# Altium schematic sheet STM32-ROMI_Rev_A_4_3V_5V.SchDoc(STM32-ROMI_Rev_A_4_3V_5V)
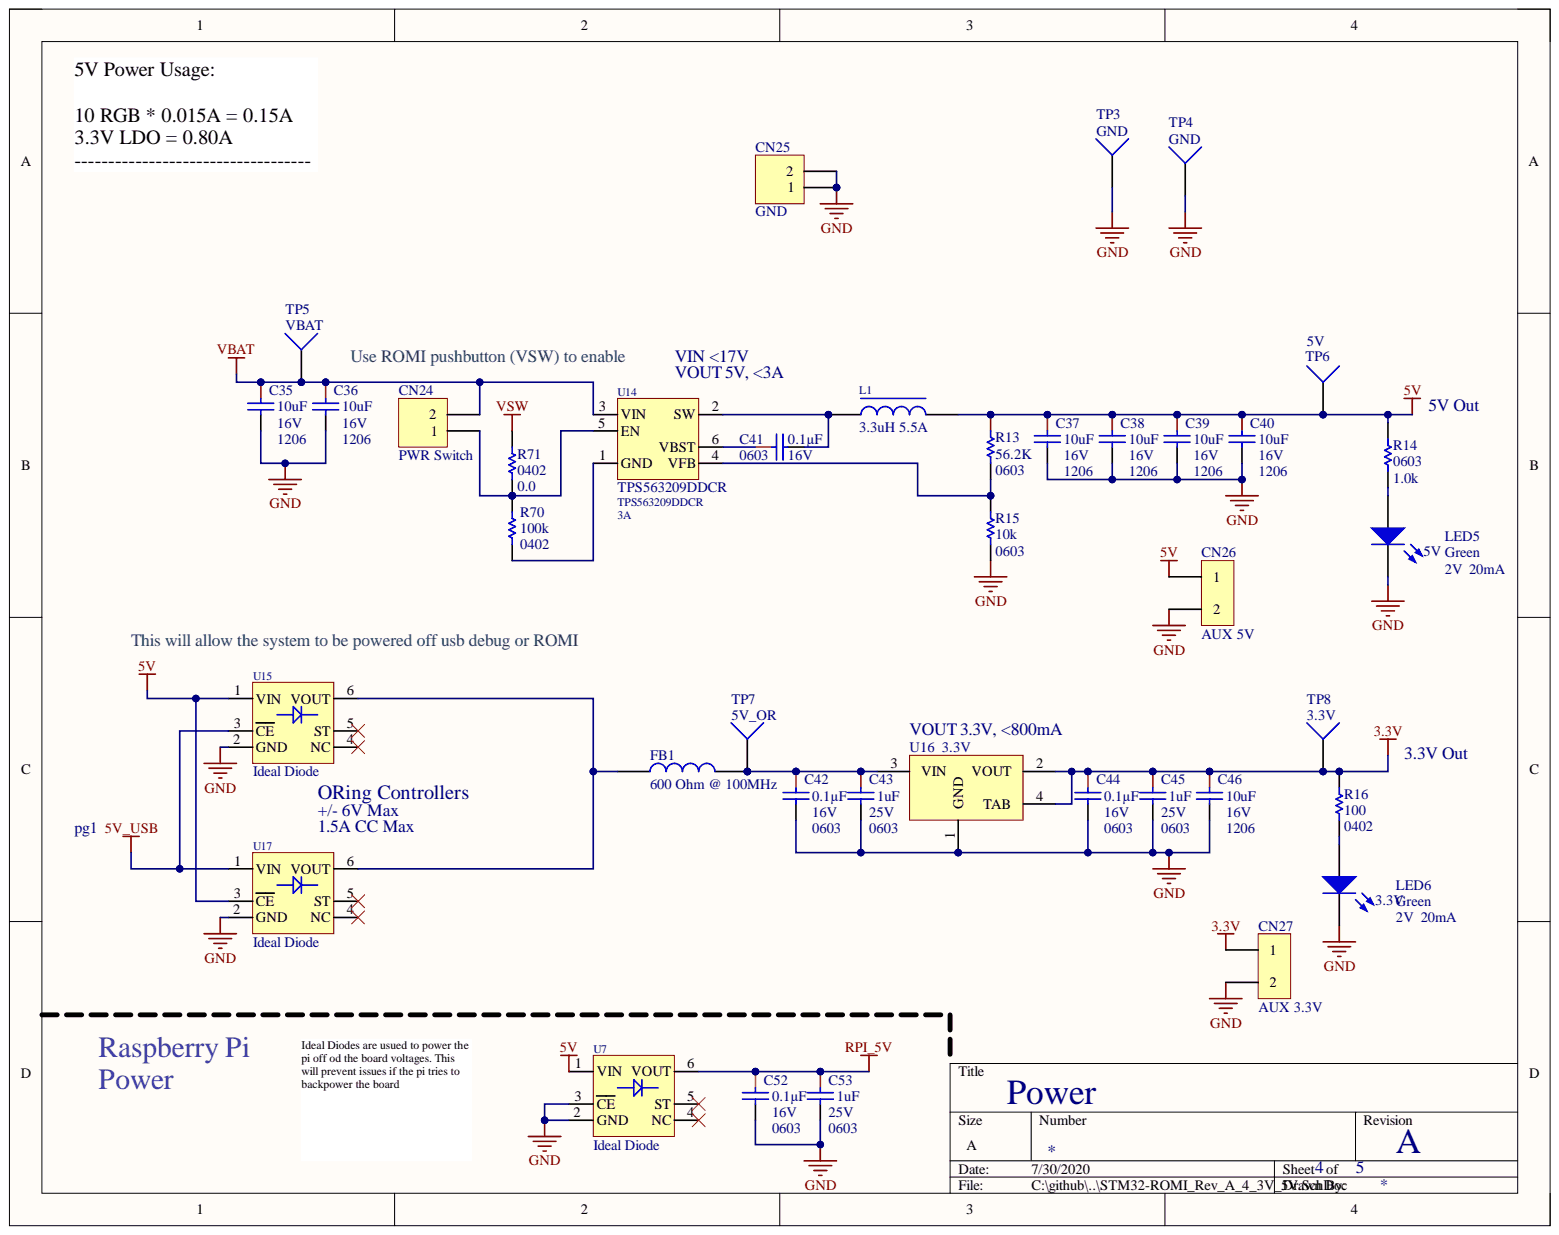
[diagram p0: STM32-ROMI_Rev_A_4_3V_5V.SchDoc]

## Parts
C35  10uF  Package: 1206  Voltage - Rated: 16V  [CAPC1206(3216)_M]
C36  10uF  Package: 1206  Voltage - Rated: 16V  [CAPC1206(3216)_M]
C37  10uF  Package: 1206  Voltage - Rated: 16V  [CAPC1206(3216)_M]
C38  10uF  Package: 1206  Voltage - Rated: 16V  [CAPC1206(3216)_M]
C39  10uF  Package: 1206  Voltage - Rated: 16V  [CAPC1206(3216)_M]
C40  10uF  Package: 1206  Voltage - Rated: 16V  [CAPC1206(3216)_M]
C41  Capacitance: 0.1µF  Package / Case: 0603  Voltage - Rated: 16V  [CAPC0603(1608)_L]  (hidden comment: 0.1uF)
C42  Capacitance: 0.1µF  Package / Case: 0603  Voltage - Rated: 16V  [CAPC0603(1608)_L]  (hidden comment: 0.1uF)
C43  1uF  Package / Case: 0603  Voltage - Rated: 25V  [CAPC0603(1608)_N]
C44  Capacitance: 0.1µF  Package / Case: 0603  Voltage - Rated: 16V  [CAPC0603(1608)_L]  (hidden comment: 0.1uF)
C45  1uF  Package / Case: 0603  Voltage - Rated: 25V  [CAPC0603(1608)_N]
C46  10uF  Package: 1206  Voltage - Rated: 16V  [CAPC1206(3216)_M]
C52  Capacitance: 0.1µF  Package / Case: 0603  Voltage - Rated: 16V  [CAPC0603(1608)_L]  (hidden comment: 0.1uF)
C53  1uF  Package / Case: 0603  Voltage - Rated: 25V  [CAPC0603(1608)_N]
CN24  PWR Switch  [HDR1X2]
CN25  GND  [HDR1X2]
CN26  AUX 5V  [Molex 1x2 Microfit 3.0]
CN27  AUX 3.3V  [Molex 1x2 Microfit 3.0]
FB1  600 Ohm @ 100MHz  [RESC0805(2012)_N]
L1  3.3uH 5.5A  [YSPI0530-3R3M]
LED5  5V  Color: Green  Voltage - Forward (Vf) (Typ): 2V  [SM-LED-0603]
LED6  3.3V  Color: Green  Voltage - Forward (Vf) (Typ): 2V  [SM-LED-0603]
R13  56.2K  Package / Case: 0603  [RESC0603(1608)_L]
R14  Package / Case: 0603  [RESC0603(1608)_N]  (hidden comment: 1.0K)
R15  Package / Case: 0603  Resistance (Ohms): 10k  [RESC0603(1608)_L]  (hidden comment: 10K 1%)
R16  100  Supplier Device Package: 0402  [RESC0402(1005)_N]
R70  Resistance (Ohms): 100k  Supplier Device Package: 0402  [RESC0402(1005)_L]  (hidden comment: 100K 0402)
R71  0  Resistance (Ohms): 0.0  Supplier Device Package: 0402  [RESC0402(1005)_L]
TP3  GND  [TestPoint-SM]  (no BOM)
TP4  GND  [TestPoint-SM]  (no BOM)
TP5  VBAT  [TestPoint-SM]  (no BOM)
TP6  5V  [TestPoint-SM]  (no BOM)
TP7  5V_OR  [TestPoint-SM]  (no BOM)
TP8  3.3V  [TestPoint-SM]  (no BOM)
U7  Ideal Diode  [SC-70-6]
U14  TPS563209DDCR  [SOT23-6]
U15  Ideal Diode  [SC-70-6]
U16  3.3V  [DSO-G3]
U17  Ideal Diode  [SC-70-6]

## Nets
3.3V: C44.1 C45.1 C46.1 CN27.1 R16.2 TP8.1 U16.2(VOUT) U16.4(TAB)
5V: C37.1 C38.1 C39.1 C40.1 CN26.1 L1.2 R13.1 R14.2 TP6.1 U7.1(VIN) U15.1(VIN) U17.3(CE)
5V_USB: U15.3(CE) U17.1(VIN)
GND: C35.2 C36.2 C37.2 C38.2 C39.2 C40.2 C42.2 C43.2 C44.2 C45.2 C46.2 C52.2 C53.2 CN25.1 CN25.2 CN26.2 CN27.2 LED5.2 LED6.2 R15.1 TP3.1 TP4.1 U7.2(GND) U7.3(CE) U15.2(GND) U16.1(GND) U17.2(GND)
RPI_5V: C52.1 C53.1 U7.6(VOUT)
VBAT: C35.1 C36.1 CN24.2 TP5.1 U14.3(VIN)
VSW: R71.1
NetC41_1: C41.1 U14.6(VBST)
NetC41_2: C41.2 L1.1 U14.2(SW)
NetC42_1: C42.1 C43.1 FB1.2 TP7.1 U16.3(VIN)
NetCN24_1: CN24.1 R70.2 R71.2 U14.5(EN)
NetFB1_1: FB1.1 U15.6(VOUT) U17.6(VOUT)
NetLED5_1: LED5.1 R14.1
NetLED6_1: LED6.1 R16.1
NetR13_2: R13.2 R15.2 U14.4(VFB)
NetR70_1: R70.1 U14.1(GND)

Not connected: U7 4(NC) 5(ST); U15 4(NC) 5(ST); U17 4(NC) 5(ST)

## Library data of the parts
C35-C40 C46 = CAP CER 10UF 16V 1206: Temperature Coefficient: X7R; Capacitance: 10uF; Component Kind: Standard; LCSC: C126600; Supplier 1: Digi-Key; Supplier Part Number 1: 490-4793-1-ND; Library Name: Kyle-Passive.IntLib
C41 C42 C44 C52 = CAP CER 0.1UF 16V 10% X7R 0603: 0.1uF 0603 16V; Manufacturer: Kemet; Manufacturer Part Number: C0603C104K4RACTU; Temperature Coefficient: X7R; Tolerance: ±10%; Applications: General Purpose; Category: Capacitors; Component Kind: Standard; ComponentLink1Description: http://www.kemet.com/datasheets&C0603C104K4RACTU; ComponentLink1URL: http://www.kemet.com/datasheets&C0603C104K4RACTU; Features: -; Height - Seated (Max): -; Lead Spacing: -; Lead Style: -; Mounting Type: Surface Mount, MLCC; Operating Temperature: -55°C ~ 125°C; Packaging: Tape & Reel (TR); Pricing 1: 4000=0.00414, 8000=0.00378, 12000=0.0036, 28000=0.00342, 100000=0.00248 (USD); Ratings: -; RoHS: RoHS Compliant; Size / Dimension: 0.063" L x 0.031" W (1.60mm x 0.80mm); Stock 1: 2360000; Supplier 1: Digi-Key; Supplier Part Number 1: 399-1096-2-ND; Thickness (Max): 0.034" (0.87mm); Library Name: Kyle-Passive.IntLib
C43 C45 C53 = CAP CER 1UF 25V 10% X7R 0603: 1uF 0603 25V; Manufacturer: TDK Corporation; Manufacturer Part Number: CGA3E1X7R1E105K080AC; Temperature Coefficient: X7R; Tolerance: ±10%; Applications: Automotive; Category: Capacitors; Component Kind: Standard; ComponentLink1Description: https://product.tdk.com/info/en/catalog/datasheets/mlcc_automotive_general_en.pdf; ComponentLink1URL: https://product.tdk.com/info/en/catalog/datasheets/mlcc_automotive_general_en.pdf; Failure Rate: -; Features: -; Height - Seated (Max): -; Lead Spacing: -; Lead Style: -; Library Name: OLogic-Passive.IntLib; Mounting Type: Surface Mount, MLCC; Operating Temperature: -55°C ~ 125°C; Packaging: Cut Tape (CT); Part Status: Active; Pricing 1: 1=0.2, 10=0.135, 100=0.0686, 500=0.04696, 1000=0.04154 (USD); Ratings: AEC-Q200; RoHS: RoHS Compliant; Size / Dimension: 0.063" L x 0.032" W (1.60mm x 0.80mm); Stock 1: 360610; Supplier 1: Digi-Key; Supplier Part Number 1: 445-6931-1-ND; Thickness (Max): 0.035" (0.90mm)
CN24 CN25 = Header 2: Header, 2-Pin; Manufacturer: Sullins Connector Solutions; Manufacturer Part Number: PRPC002SAAN-RC; Connector Type: Header, Unshrouded, Breakaway; Number of Positions: 2; Number of Positions Loaded: All; Pitch: 0.100" (2.54mm); Category: Connectors, Interconnects; Color: Black; Component Kind: Standard; ComponentLink1Description: http://media.digikey.com/PDF/Data%20Sheets/Sullins%20PDFs/xRxCzzzSxxN-RC_ST_11635-B.pdf; ComponentLink1URL: http://media.digikey.com/PDF/Data%20Sheets/Sullins%20PDFs/xRxCzzzSxxN-RC_ST_11635-B.pdf; Contact Finish: Gold; Contact Finish Thickness: Flash; Contact Mating Length: 0.230" (5.84mm); Contact Type: Male Pin; Fastening Type: -; Features: -; Library Name: OLogic-Connectors.IntLib; Mounting Type: Through Hole; Number of Rows: 1; Packaging: Bulk; Pricing 1: 1=0.16, 10=0.145, 100=0.1198, 500=0.09466, 1000=0.08127, 5000=0.07414, 10000=0.07129 (USD); RoHS: RoHS Compliant; Row Spacing: -; Stock 1: 4300; Supplier 1: Digi-Key; Supplier Part Number 1: S1011EC-02-ND; Termination: Solder
CN26 CN27 = MICROFIT 3.0 HDR VERT CPI 2CKT: Molex Microfit 1x2 Vert Header; Manufacturer: Molex, LLC; Manufacturer Part Number: 0430450227; Number of Positions: 2; Number of Positions Loaded: All; Row Spacing: 0.118" (3.00mm); Shrouding: Shrouded - 4 Wall; Category: Connectors, Interconnects; Color: Black; Component Kind: Standard; ComponentLink1Description: http://www.molex.com/pdm_docs/sd/430450227_sd.pdf; ComponentLink1URL: http://www.molex.com/pdm_docs/sd/430450227_sd.pdf; Connector Type: Header; Contact Finish: Tin; Contact Finish Thickness: 60µin (1.52µm); Contact Mating Length: -; Contact Type: Male Pin; Fastening Type: Locking Ramp; Features: Board Guide; Library Name: OLogic-Connectors.IntLib; Mounting Type: Through Hole; Number of Rows: 2; Packaging: Tray; Part Status: Active; Pitch: -; Pricing 1: 1=0.8, 10=0.705, 50=0.636, 100=0.6083, 250=0.553, 500=0.4977, 1000=0.4424, 2500=0.40092, 5000=0.3871 (USD); RoHS: RoHS Compliant; Stock 1: 4002; Supplier 1: Digi-Key; Supplier Part Number 1: WM10657-ND; Termination: Solder
FB1 = FERRITE 600 OHM 2.0A 0805: 600 OHM 0805 2.0A; Manufacturer: Wurth Electronics Inc; Manufacturer Part Number: 742792040; Current Rating: 2A; DC Resistance (DCR): 150 mOhm Max; Filter Type: Differential Mode - Single; Category: Filters; Component Kind: Standard; ComponentLink1Description: http://katalog.we-online.de/pbs/datasheet/742792040.pdf; ComponentLink1URL: http://katalog.we-online.de/pbs/datasheet/742792040.pdf; Height (Max): 0.043" (1.10mm); Impedance @ Frequency: 600 Ohm @ 100MHz; Library Name: OLogic-Passive.IntLib; Mounting Type: Surface Mount; Package / Case: 0805 (2012 Metric); Packaging: Cut Tape (CT); Pricing 1: 1=0.24, 50=0.2344, 100=0.2156, 500=0.20624, 1000=0.19687, 2000=0.1875 (USD); RoHS: RoHS Compliant; Size / Dimension: 0.079" L x 0.047" W (2.00mm x 1.20mm); Stock 1: 34670; Supplier 1: Digi-Key; Supplier Part Number 1: 732-1620-1-ND; Creator: osman
L1 = FIXED IND 3.3UH 5.5A 28 MOHM SMD: Power Inductors 3.3uH ±20% 5.5A SMD,5.2x4.7x3mm RoHS; Component Kind: Standard; ComponentLink1Description: https://datasheet.lcsc.com/szlcsc/1812181731_YJYCOIN-YSPI0530-3R3M_C351097.pdf; ComponentLink1URL: https://datasheet.lcsc.com/szlcsc/1812181731_YJYCOIN-YSPI0530-3R3M_C351097.pdf; LCSC: C351097; Manufacturer Part: YSPI0530-3R3M; Library Name: Kyle-Passive.SCHLIB
LED5 LED6 = LTST-C191KGKT: LED GREEN CLEAR THIN 0603 SMD; Manufacturer: Lite-On Inc; Current - Test: 20mA; Lens Style/Size: Rectangle with Flat Top, 1.1mm x 0.8mm; Millicandela Rating: 35mcd; Viewing Angle: 130°; Wavelength - Dominant: 571nm; Wavelength - Peak: 574nm; Category: Optoelectronics; Component Kind: Standard; ComponentLink1Description: http://optoelectronics.liteon.com/upload/download/DS22-2000-228/S_110_LTST-C191KGKT.pdf; ComponentLink1URL: http://optoelectronics.liteon.com/upload/download/DS22-2000-228/S_110_LTST-C191KGKT.pdf; Height: 0.55mm; Lens Type: Clear; Luminous Flux @ Current - Test: -; Manufacturer Part Number: LTST-C191KGKT; Mounting Type: Surface Mount; Package / Case: 0603 (1608 Metric); Packaging: Cut Tape (CT); Pricing 1: 1=0.3, 10=0.238, 100=0.1296, 250=0.0864, 500=0.07344, 1000=0.05616, 2500=0.05076 (USD); RoHS: RoHS Compliant; Size / Dimension: 1.60mm L x 0.80mm W; Stock 1: 84829; Supplier 1: Digi-Key; Supplier Part Number 1: 160-1446-1-ND; Library Name: Kyle-Diode-Opto-LED.IntLib
R13 = RES SMD 56.2K OHM 1% 1/10W 0603: Power (Watts): 0.1W; Temperature Coefficient: ±100ppm/°C; Component Kind: Standard; Description: RES SMD 56.2K OHM 1% 1/10W 0603; Resistance: 56.2K; Supplier 1: Digi-Key; Supplier Part Number 1: P56.2KHCT-ND; Library Name: Kyle-Passive.IntLib
R14 = RES 1K OHM 1% 1/10W 0603: 1.0K 0603; Manufacturer: Stackpole Electronics Inc.; Manufacturer Part Number: RMCF0603FT1K00; Power (Watts): 0.1W, 1/10W; Temperature Coefficient: ±100ppm/°C; Tolerance: ±1%; Category: Resistors; Component Kind: Standard; ComponentLink1Description: https://www.seielect.com/Catalog/SEI-RMCF_RMCP.pdf; ComponentLink1URL: https://www.seielect.com/Catalog/SEI-RMCF_RMCP.pdf; Composition: Thick Film; Failure Rate: -; Features: Automotive AEC-Q200; Height - Seated (Max): 0.022" (0.55mm); Operating Temperature: -55°C ~ 155°C; Packaging: Cut Tape (CT); Part Status: Active; Pricing 1: 1=0.1, 10=0.02, 100=0.0081, 1000=0.00364, 2500=0.00316 (USD); Resistance (Ohms): 1.0k; RoHS: RoHS Compliant; Size / Dimension: 0.061" L x 0.031" W (1.55mm x 0.80mm); Stock 1: 411308; Supplier 1: Digi-Key; Supplier Device Package: 0603; Supplier Part Number 1: RMCF0603FT1K00CT-ND; Library Name: Kyle-Passive.IntLib
R15 = RES 10K OHM 1/10W 1% 0603 SMD: 10K 0603; Manufacturer: Panasonic Electronic Components; Manufacturer Part Number: ERJ-3EKF1002V; Power (Watts): 0.1W, 1/10W; Temperature Coefficient: ±100ppm/°C; Category: Resistors; Component Kind: Standard; ComponentLink1Description: http://industrial.panasonic.com/www-cgi/jvcr13pz.cgi?E+PZ+3+AOA0002+ERJ3EKF1002V+7+WW; ComponentLink1URL: http://industrial.panasonic.com/www-cgi/jvcr13pz.cgi?E+PZ+3+AOA0002+ERJ3EKF1002V+7+WW; Composition: Thick Film; Features: -; Height: 0.022" (0.55mm); Number of Terminations: 2; Packaging: Cut Tape (CT); Pricing 1: 1=0.1, 50=0.015, 100=0.0114, 250=0.00844, 500=0.00624, 1000=0.00416, 2500=0.00351 (USD); RoHS: RoHS Compliant; Signal Integrity: Res; Simulation: RESISTOR; Size / Dimension: 0.063" L x 0.031" W (1.60mm x 0.80mm); Stock 1: 5046430; Supplier 1: Digi-Key; Supplier Device Package: 0603; Supplier Part Number 1: P10.0KHCT-ND; Tolerance: ±1%; Library Name: Kyle-Passive.IntLib
R16 = RES 100 OHM 1% 1/16W 0402: 100 0402; Manufacturer: Yageo; Manufacturer Part Number: RC0402FR-07100RL; Power (Watts): 0.063W, 1/16W; Temperature Coefficient: ±100ppm/°C; Tolerance: ±1%; Category: Resistors; Component Kind: Standard; ComponentLink1Description: http://www.yageo.com/documents/recent/PYu-RC_Group_51_RoHS_L_8.pdf; ComponentLink1URL: http://www.yageo.com/documents/recent/PYu-RC_Group_51_RoHS_L_8.pdf; Composition: Thick Film; Failure Rate: -; Features: Moisture Resistant; Height - Seated (Max): 0.016" (0.40mm); Library Name: OLogic-Passive.IntLib; Operating Temperature: -55°C ~ 155°C; Package / Case: 0402 (1005 Metric); Packaging: Cut Tape (CT); Part Status: Active; Pricing 1: 1=0.1, 10=0.014, 100=0.0056, 1000=0.00253, 2500=0.0022, 5000=0.00181 (USD); RoHS: RoHS Compliant; Size / Dimension: 0.039" L x 0.020" W (1.00mm x 0.50mm); Stock 1: 14557677; Supplier 1: Digi-Key; Supplier Part Number 1: 311-100LRCT-ND
R70 = RES 100K OHM 1% 1/16W 0402: Manufacturer: Yageo; Manufacturer Part Number: RC0402FR-07100KL; Power (Watts): 0.1W, 1/10W; Temperature Coefficient: ±100ppm/°C; Tolerance: ±1%; Category: Resistors; Component Kind: Standard; ComponentLink1Description: http://industrial.panasonic.com/www-cgi/jvcr13pz.cgi?E+PZ+3+AOA0002+ERJ2RKF1003X+7+WW; ComponentLink1URL: http://industrial.panasonic.com/www-cgi/jvcr13pz.cgi?E+PZ+3+AOA0002+ERJ2RKF1003X+7+WW; Composition: Thick Film; Description: 100K 0402; Features: Automotive AEC-Q200; Height: 0.016" (0.40mm); Library Name: OLogic-Passive.IntLib; Number of Terminations: 2; Operating Temperature: -55°C ~ 155°C; Package / Case: 0402 (1005 Metric); Packaging: Cut Tape (CT); Pricing 1: 1=0.1, 50=0.0196, 100=0.0149, 250=0.01104, 500=0.00816, 1000=0.00544, 5000=0.00383 (USD); RoHS: RoHS Compliant; Size / Dimension: 0.039" L x 0.020" W (1.00mm x 0.50mm); Stock 1: 3706939; Supplier 1: Digi-Key; Supplier 2: Digi-Key; Supplier Part Number 1: 311-100KLRCT-ND; Supplier Part Number 2: RMCF0402FT100KCT-ND
R71 = RES 0.0 OHM JUMPER 1/10W 0402: 0.0 0402; Manufacturer: Panasonic Electronic Components; Manufacturer Part Number: ERJ-2GE0R00X; Power (Watts): 0.1W, 1/10W; Tolerance: Jumper; Category: Resistors; Component Kind: Standard; ComponentLink1Description: http://industrial.panasonic.com/www-cgi/jvcr13pz.cgi?E+PZ+3+AOA0001+ERJ2GE0R00X+7+WW; ComponentLink1URL: http://industrial.panasonic.com/www-cgi/jvcr13pz.cgi?E+PZ+3+AOA0001+ERJ2GE0R00X+7+WW; Composition: Thick Film; Features: Automotive AEC-Q200; Height: 0.016" (0.40mm); Library Name: OLogic-Passive.IntLib; Number of Terminations: 2; Operating Temperature: -55°C ~ 155°C; Package / Case: 0402 (1005 Metric); Packaging: Cut Tape (CT); Pricing 1: 1=0.1, 50=0.0138, 100=0.0105, 250=0.0078, 500=0.00576, 1000=0.00384, 5000=0.0027 (USD); RoHS: RoHS Compliant; Size / Dimension: 0.039" L x 0.020" W (1.00mm x 0.50mm); Stock 1: 8429476; Supplier 1: Digi-Key; Supplier Part Number 1: P0.0JCT-ND; Temperature Coefficient: -; Creator: osman
TP3-TP8 = TestPoint: Test Point; Library Name: OLogic-Misc.IntLib
U7 U15 U17 = LM66100DCKT: OR Controller P-Channel 1.5A CC; Component Kind: Standard; Library Name: Kyle-Reg-Convert.IntLib
U14 = TPS563209DDCR: IC REG BUCK ADJ 3A TSOT23-6; Frequency - Switching: 650KHZ; Voltage - Input (Max): 17V; Voltage - Input (Min): 4.5V; Voltage - Output (Max): 7V; Voltage - Output (Min/Fixed): 0.765V; Component Kind: Standard; Current - Output: 3A; Manufacturer Part Number: TPS563209DDCR; Supplier 1: Digi-Key; Supplier Device Package: TSOT-23-6; Supplier Part Number 1: 296-40813-1-ND; Topology: Buck; Library Name: Kyle-Reg-Convert.SCHLIB
U16 = LD1117S33: 3.3 volt fixed regulator, 800mA; Component Kind: Standard; Supplier 1: Digi-Key; Supplier Part Number 1: 497-1242-1-ND; Library Name: OLogic-Reg-Convert.IntLib
